# Altium schematic sheet Communication_Interface.SchDoc(Communication_Interface)
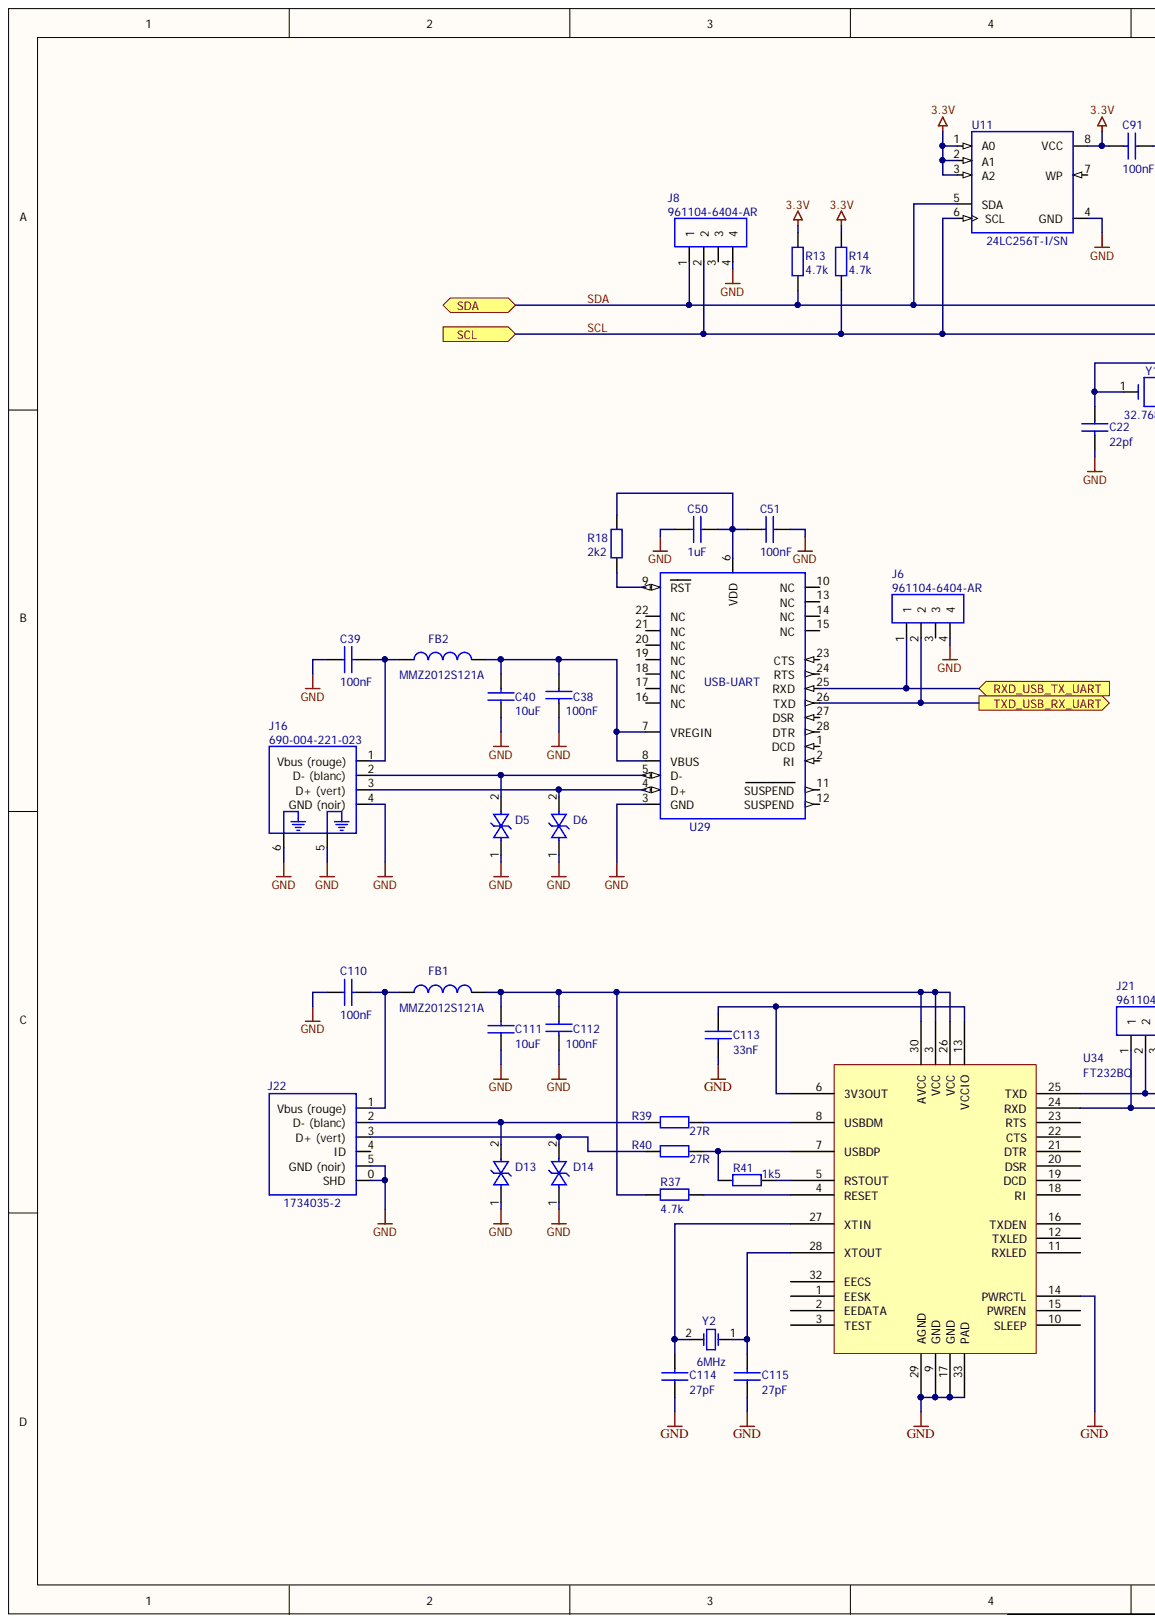
[diagram p0: Communication_Interface.SchDoc, left]
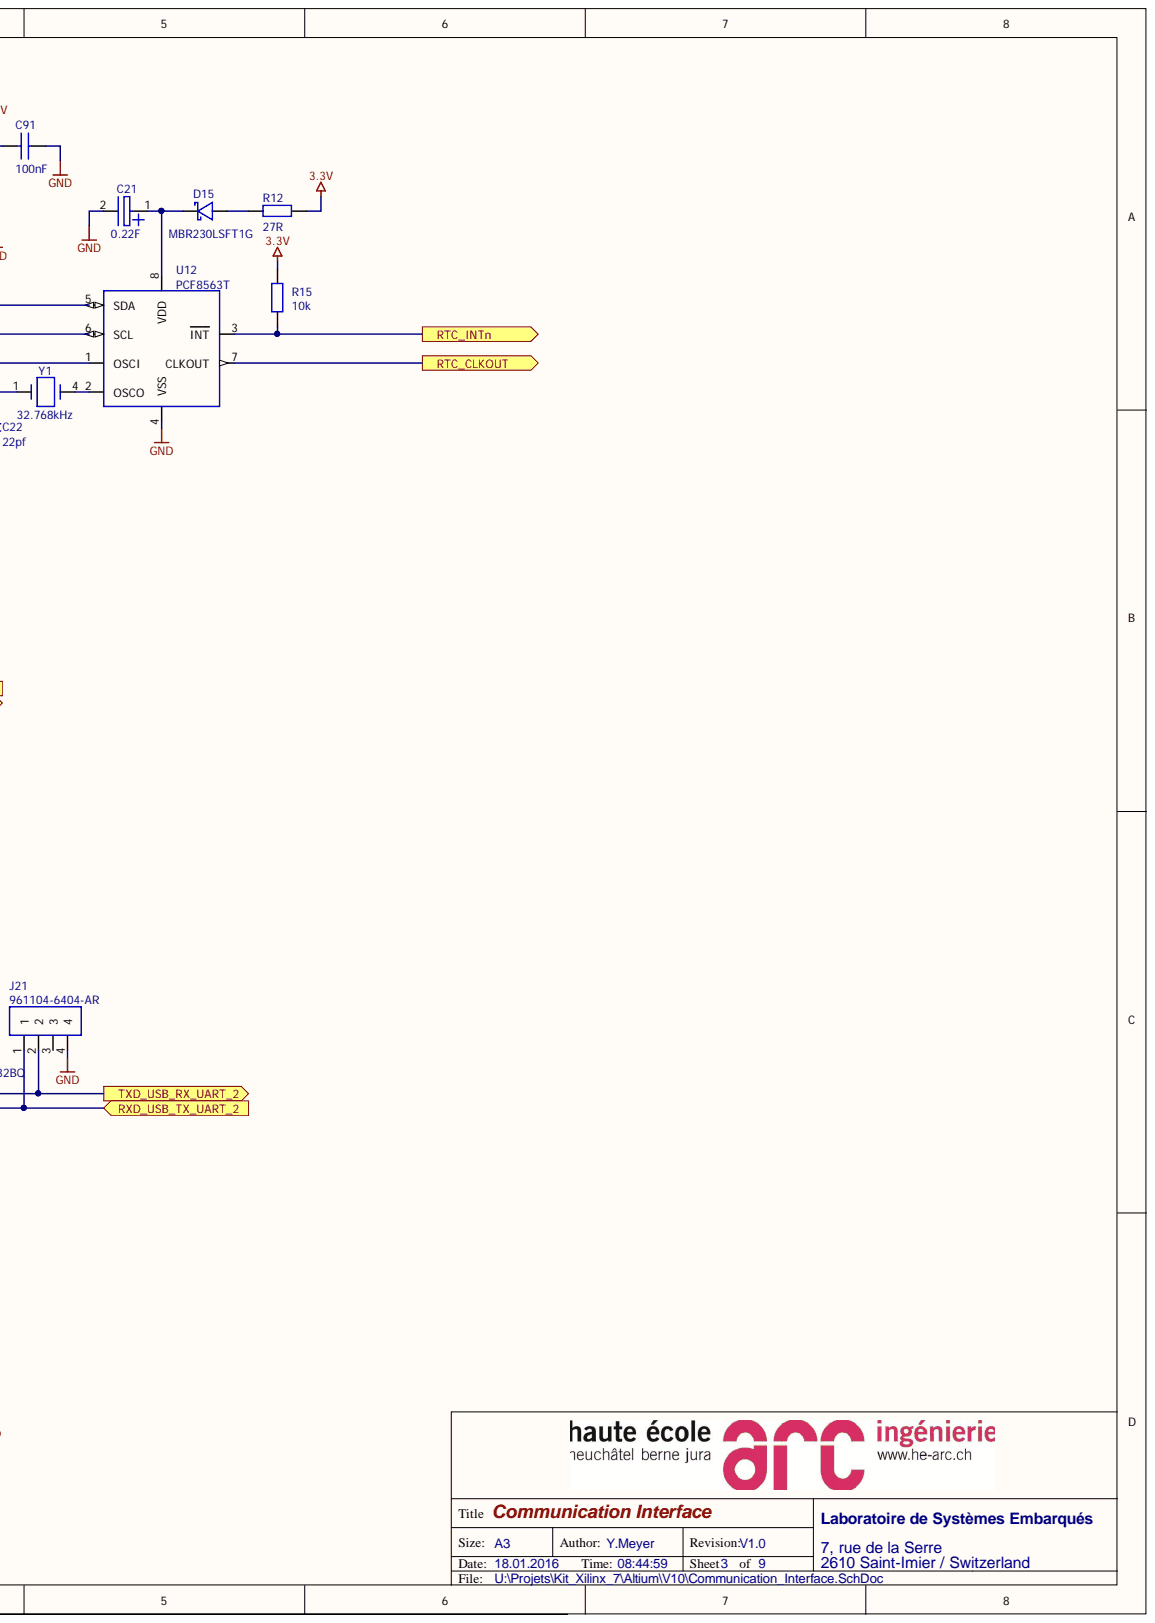
[diagram p1: Communication_Interface.SchDoc, right]

## Parts
C21  value 0.22F  [C001801]  (hidden comment: DSK-3R3H224U-HL)
C22  value 22pf  [060301]  (hidden comment: C1608C0G1H220J080AA)
C38  value 100nF  [060301]  (hidden comment: C0603C104Z3VAC7867)
C39  value 100nF  [060301]  (hidden comment: C0603C104Z3VAC7867)
C40  value 10uF  [080501]  (hidden comment: C2012X6S1C106M125AC)
C50  value 1uF  [060301]  (hidden comment: C0603C105K4RACTU)
C51  value 100nF  [060301]  (hidden comment: C0603C104Z3VAC7867)
C91  value 100nF  [060301]  (hidden comment: C0603C104Z3VAC7867)
C110  value 100nF  [060301]  (hidden comment: C0603C104Z3VAC7867)
C111  value 10uF  [080501]  (hidden comment: C2012X6S1C106M125AC)
C112  value 100nF  [060301]  (hidden comment: C0603C104Z3VAC7867)
C113  value 33nF  [060301]  (hidden comment: GRM188R71H333KA61D)
C114  value 27pF  [060301]  (hidden comment: 06035A270JAT2A)
C115  value 27pF  [060301]  (hidden comment: 06035A270JAT2A)
D5  PGB1010603MR  [060301]  (value not drawn)
D6  PGB1010603MR  [060301]  (value not drawn)
D13  PGB1010603MR  [060301]  (value not drawn)
D14  PGB1010603MR  [060301]  (value not drawn)
D15  MBR230LSFT1G  [SOD123LF]
FB1  MMZ2012S121A  [080501]
FB2  MMZ2012S121A  [080501]
J6  961104-6404-AR  [J104A101]
J8  961104-6404-AR  [J104A101]
J16  690-004-221-023  [USB2.5-2H4D]
J21  961104-6404-AR  [J104A101]
J22  1734035-2  [MINI-USB]
R12  value 27R  [060301]  (hidden comment: RC0603FR-0727RL)
R13  value 4.7k  [060301]  (hidden comment: ERJ-3EKF4701V)
R14  value 4.7k  [060301]  (hidden comment: ERJ-3EKF4701V)
R15  value 10k  [060301]  (hidden comment: RC0603FR-0710KL)
R18  value 2k2  [060301]  (hidden comment: RC0603FR-072K2L)
R37  value 4.7k  [060301]  (hidden comment: ERJ-3EKF4701V)
R39  value 27R  [060301]  (hidden comment: RC0603FR-0727RL)
R40  value 27R  [060301]  (hidden comment: RC0603FR-0727RL)
R41  value 1k5  [060301]  (hidden comment: RC0603FR-071K5L)
U11  24LC256T-I/SN  [SO801]
U12  PCF8563T  [SO801]
U29  CP2102-GM  [QFN-28]  (value not drawn)
U34  FT232BQ  [QFN32]
Y1  value 32.768kHz  [XT-5]  (hidden comment: ECS-.327-12.5-17X)
Y2  value 6MHz  [MQ]  (hidden comment: 6.000MHZ MQ/30/30/40/12PF)

## Ports
RTC_CLKOUT, RTC_INTN, RXD_USB_TX_UART, RXD_USB_TX_UART_2, SCL, SDA, TXD_USB_RX_UART, TXD_USB_RX_UART_2

## Nets
3.3V: C91.2 R12.2 R13.2 R14.2 R15.1 U11.1(A0) U11.2(A1) U11.3(A2) U11.8(VCC)
GND: C21.2 C22.2 C38.2 C39.1 C40.1 C50.2 C51.1 C91.1 C110.1 C111.1 C112.2 C113.2 C114.1 C115.1 D5.1 D6.1 D13.1 D14.1 J6.4 J8.4 J16.4(GND_(noir)) J16.5 J16.6 J21.4 J22.0(SHD) J22.5(GND_(noir)) U11.4(GND) U12.4(VSS) U29.3(GND) U34.9(GND) U34.14(PWRCTL) U34.17(GND) U34.29(AGND) U34.33(PAD)
RTC_INTN: R15.2 U12.3(INT) ; port RTC_INTN
RTC_CLKOUT: U12.7(CLKOUT) ; port RTC_CLKOUT
RXD_USB_TX_UART: J6.1 U29.25(RXD) ; port RXD_USB_TX_UART
RXD_USB_TX_UART_2: J21.1 U34.24(RXD) ; port RXD_USB_TX_UART_2
SCL: J8.2 R14.1 U11.6(SCL) U12.6(SCL) ; port SCL
SDA: J8.1 R13.1 U11.5(SDA) U12.5(SDA) ; port SDA
TXD_USB_RX_UART: J6.2 U29.26(TXD) ; port TXD_USB_RX_UART
TXD_USB_RX_UART_2: J21.2 U34.25(TXD) ; port TXD_USB_RX_UART_2
NetC21_1: C21.1 D15.1 U12.8(VDD)
NetC22_1: C22.1 U12.1(OSCI) Y1.1
NetC38_1: C38.1 C40.2 FB2.2 U29.7(VREGIN) U29.8(VBUS)
NetC39_2: C39.2 FB2.1 J16.1(Vbus_(rouge))
NetC50_1: C50.1 C51.2 R18.2 U29.6(VDD)
NetC110_2: C110.2 FB1.1 J22.1(Vbus_(rouge))
NetC111_2: C111.2 C112.1 FB1.2 R37.2 U34.3 U34.26(VCC) U34.30(AVCC)
NetC113_1: C113.1 U34.6(3V3OUT) U34.13(VCCIO)
NetC114_2: C114.2 U34.27(XTIN) Y2.2
NetC115_2: C115.2 U34.28(XTOUT) Y2.1
NetD5_2: D5.2 J16.2(D-_(blanc)) U29.5(D-)
NetD6_2: D6.2 J16.3(D+_(vert)) U29.4(D+)
NetD13_2: D13.2 J22.2(D-_(blanc)) R39.1
NetD14_2: D14.2 J22.3(D+_(vert)) R40.1
NetD15_2: D15.2 R12.1
NetR18_1: R18.1 U29.9(RST)
NetR37_1: R37.1 U34.4(RESET)
NetR39_2: R39.2 U34.8(USBDM)
NetR40_2: R40.2 R41.2 U34.7(USBDP)
NetR41_1: R41.1 U34.5(RSTOUT)
NetU12_2: U12.2(OSCO) Y1.4

Not connected: J6 3; J8 3; J21 3; J22 4(ID); U11 7(WP); U29 1(DCD) 2(RI) 10(NC) 11(SUSPEND) 12(SUSPEND) 13(NC) 14(NC) 15(NC) 16(NC) 17(NC) 18(NC) 19(NC) 20(NC) 21(NC) 22(NC) 23(CTS) 24(RTS) 27(DSR) 28(DTR); U34 1(EESK) 2(EEDATA) 10(SLEEP) 11(RXLED) 12(TXLED) 15(PWREN) 16(TXDEN) 18(RI) 19(DCD) 20(DSR) 21(DTR) 22(CTS) 23(RTS) 32(EECS)

## Library data of the parts
C21 = CAPA_EL: CAP 220MF -20% +80% 3.3V SMD; Component Kind: Standard; Supplier 1: Digi-Key; Supplier Part Number 1: 604-1020-1-ND; Library Name: EISI.SchLib
C22 = CAPA: CAP CER 22PF 50V C0G 0603; Component Kind: Standard; Supplier 1: Digi-Key; Supplier Part Number 1: 445-1273-1-ND; Library Name: EISI.SchLib
C38 C39 C51 C91 C110 C112 = CAPA: CAP .10UF 25V CERAMIC Y5V 0603; Component Kind: Standard; Supplier 1: Digi-Key; Supplier Part Number 1: 399-1100-2-ND; Library Name: EISI.SchLib
C40 C111 = CAPA: CAP CER 10UF 16V 20% X6S 0805; Component Kind: Standard; Signal Integrity: Cap Semi; Simulation: CAP; Supplier 1: Digi-Key; Supplier Part Number 1: 445-7612-2-ND; Library Name: EISI.SchLib
C50 = CAPA: CAP CER 1UF 16V 10% X7R 0603; Component Kind: Standard; Supplier 1: Digi-Key; Supplier Part Number 1: 399-7847-6-ND; Library Name: EISI.SchLib
C113 = CAPA: CAP CER 0.033UF 50V 10% X7R 0603; Component Kind: Standard; Supplier 1: Digi-Key; Supplier Part Number 1: 490-3286-2-ND; Library Name: EISI.SchLib
C114 C115 = CAPA: CAP CER 27PF 50V NP0 0603; Component Kind: Standard; Supplier 1: Digi-Key; Supplier Part Number 1: 478-1168-1-ND; Library Name: EISI.SchLib
D5 D6 D13 D14 = Transil: SUPPRESSOR ESD 24VDC 0603 SMD; Component Kind: Standard; Supplier 1: Digi-Key; Supplier Part Number 1: F2594CT-ND; Library Name: EISI.SchLib
D15 = MBR230LSFT1G: DIODE SCHOTTKY 30V 2A SOD123L; Component Kind: Standard; Library Name: PowerBoard.SchLib; Supplier 1: Digi-Key; Supplier Part Number 1: MBR230LSFT1GOSCT-ND
FB1 FB2 = INDUCTOR: FERRITE CHIP 120 OHM 800MA 0805; Component Kind: Standard; Supplier 1: Digi-Key; Supplier Part Number 1: 445-2193-2-ND
J6 J8 J21 = CON4: CONN HEADER VERT SGL 4POS GOLD; Component Kind: Standard; Supplier 1: Digi-Key; Supplier Part Number 1: 3M9449-ND; Library Name: EISI.SchLib
J16 = CON USB: CONN USB JACK TYPE B HORIZON R/A; Component Kind: Standard; Signal Integrity: Connector; Supplier 1: Digi-Key; Supplier Part Number 1: 151-1121-ND; Library Name: EISI.SchLib
J22 = CON USB: CONN MINI USB RCPT RA TYPE B SMD; Component Kind: Standard; Library Name: KL46-Robot.SCHLIB; Supplier 1: Digi-Key; Supplier Part Number 1: A31727CT-ND
R12 R39 R40 = RES: RES SMD 27 OHM 1% 1/10W 0603; Component Kind: Standard; Revision: July-2002: Re-released for DXP Platform.; Supplier 1: Digi-Key; Supplier Part Number 1: 311-27.0HRCT-ND; Library Name: EISI.SchLib
R13 R14 R37 = RES: RES 4.70K OHM 1/10W 1% 0603 SMD; Component Kind: Standard; Supplier 1: Digi-Key; Supplier Part Number 1: P4.70KHCT-ND; Library Name: EISI.SchLib
  R37: Revision: July-2002: Re-released for DXP Platform.
R15 = RES: RES 10.0K OHM 1/10W 1% 0603 SMD; Component Kind: Standard; Supplier 1: Digi-Key; Supplier Part Number 1: 311-10.0KHRCT-ND; Library Name: EISI.SchLib
R18 = RES: RES 2.20K OHM 1/10W 1% 0603 SMD; Component Kind: Standard; Supplier 1: Digi-Key; Supplier Part Number 1: 311-2.20KHRCT-ND; Library Name: EISI.SchLib
R41 = RES: RES SMD 1.5K OHM 1% 1/10W 0603; Component Kind: Standard; Revision: July-2002: Re-released for DXP Platform.; Supplier 1: Digi-Key; Supplier Part Number 1: 311-1.50KHRCT-ND; Library Name: EISI.SchLib
U11 = 24LC256I/SN: IC SERIAL EEPROM 256K 2.5V 8SOIC; Component Kind: Standard; Supplier 1: Digi-Key; Supplier Part Number 1: 24LC256T-I/SNDKR-ND; Library Name: EISI.SchLib
U12 = PCF8563: Real-time clock/calendar; Component Kind: Standard; Supplier 1: Digi-Key; Supplier Part Number 1: 568-1068-1-ND; Library Name: EISI.SchLib
U29 = CP2102: IC USB-TO-UART BRIDGE 28VQFN; Component Kind: Standard; Supplier 1: Digi-Key; Supplier Part Number 1: 336-1160-5-ND; Library Name: EISI.SchLib
U34 = FT232BL: IC USB SERIAL UART 32-QFN; Component Kind: Standard; Library Name: KitXilinx7.SCHLIB; Supplier 1: Digi-Key; Supplier Part Number 1: 768-1067-1-ND
Y1 = Crystal_32: Crystal, 32.768KHz, SMT; Component Kind: Standard; Supplier 1: Digi-Key; Supplier Part Number 1: XC1195DKR-ND
Y2 = XTAL: QUARZ, 5X7MM, KERAMIK, 6MHZ; Component Kind: Standard; Revision: July-2002: Re-released for DXP Platform.; Simulation: XTAL; Supplier 1: Farnell; Supplier Part Number 1: 1640909; Library Name: EISI.SchLib
